# Altium schematic sheet MCU.SchDoc
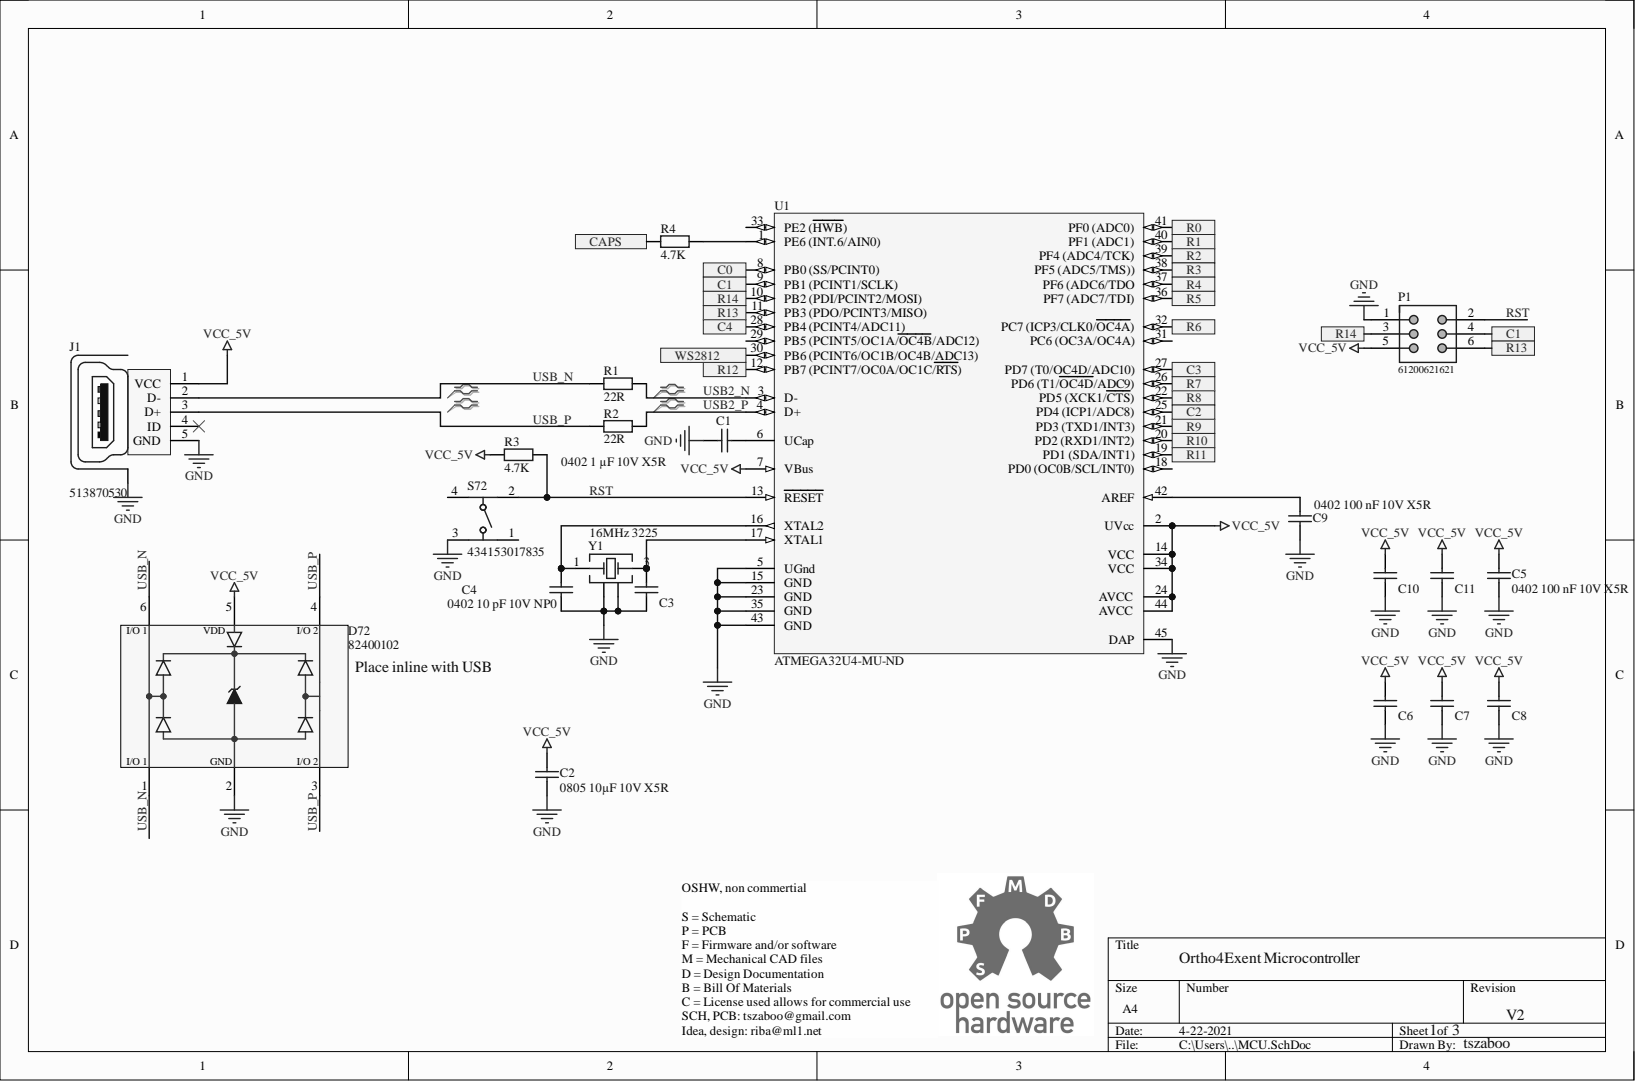
[diagram p0: MCU.SchDoc]

## Parts
C1  0402 1µF  value 1 µF  [CAPC0402N]
C2  0805 10µF  value 10 µF  [CAPC0805N]
C3  0402 10pF  [CAPC0402N]
C4  0402 10pF  value 10 pF  TECH: NP0  [CAPC0402N]
C5  0402 100nF  value 100 nF  [CAPC0402N]
C6  0402 100nF  [CAPC0402N]
C7  0402 100nF  [CAPC0402N]
C8  0402 100nF  [CAPC0402N]
C9  0402 100nF  value 100 nF  [CAPC0402N]
C10  0402 100nF  [CAPC0402N]
C11  0402 100nF  [CAPC0402N]
D72  82400102  [SOT23-6L]
J1  513870530  [513870530]
P1  61200621621  [61200621621]
R1  value 22R  [RESC0603N]  (hidden comment: RES 22R 0603)
R2  value 22R  [RESC0603N]  (hidden comment: RES 22R 0603)
R3  value 4.7K  [RESC0402N]  (hidden comment: RES 4.7K 0402)
R4  value 4.7K  [RESC0402N]  (hidden comment: RES 4.7K 0402)
S72  434153017835  [434153017835]
U1  ATMEGA32U4-MU-ND  [44PW_M]
Y1  16MHz 3225  [WE-XTAL_CFPX-180]

## Ports
C0, C1, C2, C3, C4, CAPS, R0, R1, R2, R3, R4, R5, R6, R7, R8, R9, R10, R11, R12, R13, R14, WS2812

## Nets
GND: C1.1 C2.1 C3.1 C4.1 C5.1 C6.1 C7.1 C8.1 C9.1 C10.1 C11.1 D72.2 J1.5(GND) J1.TAB P1.1 S72.3 U1.5(UGnd) U1.15(GND) U1.23(GND) U1.35(GND) U1.43(GND) U1.45(DAP) Y1.2 Y1.4
VCC_5V: C2.2 C5.2 C6.2 C7.2 C8.2 C10.2 C11.2 D72.5 J1.1(VCC) P1.5 R3.2 U1.2(UVcc) U1.7(VBus) U1.14(VCC) U1.24(AVCC) U1.34(VCC) U1.44(AVCC)
C0: U1.8(PB0_(SS/PCINT0)) ; port C0
C1: P1.4 U1.9(PB1_(PCINT1/SCLK)) ; port C1
C2: U1.25(PD4_(ICP1/ADC8)) ; port C2
C3: U1.27(PD7_(T0/OC4D/ADC10)) ; port C3
C4: U1.28(PB4_(PCINT4/ADC11)) ; port C4
CAPS: R4.2 ; port CAPS
R0: U1.41(PF0_(ADC0)) ; port R0
R1: U1.40(PF1_(ADC1)) ; port R1
R2: U1.39(PF4_(ADC4/TCK)) ; port R2
R3: U1.38(PF5_(ADC5/TMS))) ; port R3
R4: U1.37(PF6_(ADC6/TDO) ; port R4
R5: U1.36(PF7_(ADC7/TDI)) ; port R5
R6: U1.32(PC7_(ICP3/CLK0/OC4A)) ; port R6
R7: U1.26(PD6_(T1/OC4D/ADC9)) ; port R7
R8: U1.22(PD5_(XCK1/CTS)) ; port R8
R9: U1.21(PD3_(TXD1/INT3)) ; port R9
R10: U1.20(PD2_(RXD1/INT2)) ; port R10
R11: U1.19(PD1_(SDA/INT1)) ; port R11
R12: U1.12(PB7_(PCINT7/OC0A/OC1C/RTS)) ; port R12
R13: P1.6 U1.11(PB3_(PDO/PCINT3/MISO)) ; port R13
R14: P1.3 U1.10(PB2_(PDI/PCINT2/MOSI)) ; port R14
RST: P1.2 R3.1 S72.2 U1.13(RESET)
USB2_N: R1.2 U1.3(D-)
USB2_P: R2.2 U1.4(D+)
USB_N: D72.1 D72.6 J1.2(D-) R1.1
USB_P: D72.3 D72.4 J1.3(D+) R2.1
WS2812: U1.30(PB6_(PCINT6/OC1B/OC4B/ADC13)) ; port WS2812
NetC1_2: C1.2 U1.6(UCap)
NetC3_2: C3.2 U1.17(XTAL1) Y1.3
NetC4_2: C4.2 U1.16(XTAL2) Y1.1
NetC9_2: C9.2 U1.42(AREF)
NetR4_1: R4.1 U1.1(PE6_(INT.6/AIN0))

Not connected: J1 4(ID); S72 1 4; U1 18(PD0_(OC0B/SCL/INT0)) 29(PB5_(PCINT5/OC1A/OC4B/ADC12)) 31(PC6_(OC3A/OC4A)) 33(PE2_(HWB))

## Library data of the parts
C1 = 885012105012: MANUF: WE; COMPATIBLES: True; Database Table Name: WCAP0402$; DESCIPTION: CAP SMD 0402 1 µF 10V X5R; NoPins: 2; SCH: 0402 1 µF 10V X5R; SUPPLY: SUB; TECH: X5R; VOLTAGE: 10; Component Kind: Standard; DIGIKEY: 732-7489-2-ND; Library Name: WCAP.Dblib; MANUFCODE: 885012105012; SMD: YES; VALUE: 1 µF
C2 = 885012107010: MANUF: WE; COMPATIBLES: True; Database Table Name: WCAP0805$; DESCIPTION: CAP SMD 0805 10 µF 10 V X5R; NoPins: 2; SCH: 0805 10µF 10V X5R; SUPPLY: SUB; TECH: X5R; VOLTAGE: 10; Component Kind: Standard; DIGIKEY: 732-7620-2-ND; Library Name: WCAP.Dblib; MANUFCODE: 885012107010; SMD: YES; VALUE: 10 µF
C3 = 885012005007: MANUF: WE; COMPATIBLES: True; Database Table Name: WCAP0402$; DESCIPTION: CAP SMD 0402 10 pF 10V NP0; NoPins: 2; SCH: 0402 10 pF 10V NP0; SUPPLY: SUB; TECH: NP0; VOLTAGE: 10; Component Kind: Standard; DIGIKEY: 732-7425-2-ND; Library Name: WCAP.Dblib; MANUFCODE: 885012005007; SMD: YES; VALUE: 10 pF
C4 = 885012005007: MANUF: WE; COMPATIBLES: True; Database Table Name: WCAP0402$; DESCIPTION: CAP SMD 0402 10 pF 10V NP0; NoPins: 2; SCH: 0402 10 pF 10V NP0; SUPPLY: SUB; VOLTAGE: 10; Component Kind: Standard; DIGIKEY: 732-7425-2-ND; Library Name: WCAP.Dblib; MANUFCODE: 885012005007; SMD: YES
C5-C11 = 885012105010: MANUF: WE; COMPATIBLES: True; Database Table Name: WCAP0402$; DESCIPTION: CAP SMD 0402 100 nF 10V X5R; NoPins: 2; SCH: 0402 100 nF 10V X5R; SUPPLY: SUB; TECH: X5R; VOLTAGE: 10; Component Kind: Standard; DIGIKEY: 732-7487-2-ND; Library Name: WCAP.Dblib; MANUFCODE: 885012105010; SMD: YES
  C6-C8 C10 C11: VALUE: 100 nF
D72 = WE-TVS, SOT23-6L, 82400102: WE-TVS TVS Diode, High Speed Series, SOT23-6L, VDC = 5V; MANUF: WE; COMPATIBLES: False; Component Kind: Standard; DESCIPTION: WE-TVS TVS Diode, High Speed Series, SOT23-6L, VDC = 5V; DIGIKEY: 732-4473-1-ND; Library Name: WE-TVS_rev16a.intlib; MANUFCODE: 82400102
J1 = 513870530: Mini USB, B-Receptacle, Right-Angle, Short Body, Surface Mount Solder Tails and Through Hole Shell Tabs, with Cover Tape, Reflow DIP Type, Lead-Free; MANUF: MOLEX; COMPATIBLES: False; DESCIPTION: USB - mini B USB 2.0 OTG Receptacle Connector 5 Position Surface Mount; Component Kind: Standard; DIGIKEY: WM3756TR-ND; Library Name: 513870530.SchLib; MANUFCODE: 513870530
P1 = 61200621621: Male Box Header WR-BHD, THT, Vertical, pitch 2.54 mm, 6 pins; COMPATIBLES: True; Component Kind: Standard; Library Name: Altium_WR-BHD (rev19a).IntLib
R1 R2 = CRCW060322R0FKEA: Resistor; MANUF: VISHAY; COMPATIBLES: True; Database Table Name: CRCW0603$; DESCIPTION: RES SMD 22 OHM 1% 1/10W 100PPM 0603; NoPins: 2; SUPPLY: SUB; Component Kind: Standard; DIGIKEY: 541-22.0HCT-ND; FARNELL: #N/A; Library Name: VISHAY CRCW.Dblib; MANUFCODE: CRCW060322R0FKEA; SCH: RES 22R 0603; SMD: YES
R3 R4 = CRCW04024K70FKED: Resistor; MANUF: VISHAY; COMPATIBLES: True; Database Table Name: CRCW0402$; DESCIPTION: RES SMD 4.7K OHM 1% 1/16W 100PPM 0402; NoPins: 2; SUPPLY: SUB; Component Kind: Standard; DIGIKEY: 541-4.70KLCT-ND; FARNELL: TBD; Library Name: VISHAY CRCW.Dblib; MANUFCODE: CRCW04024K70FKED; SCH: RES 4.7K 0402; SMD: YES
S72 = 434153017835: WS-TASV J-Bend SMT Tact Switch, 3.5x2.9x1.7mm, 350gf; MANUF: WE; COMPATIBLES: True; DESCIPTION: SWITCH TACTILE SPST-NO 0.05A 12V; Component Kind: Standard; DIGIKEY: 732-10143-1-ND; Library Name: Altium_WS-TASV (rev20b).IntLib; MANUFCODE: 434153017835
U1 = CMP-0095-00166-2: 8-bit AVR Microcontroller, 2.7-5.5V, 16MHz, 32KB Flash, 1KB EEPROM, 2.5KB SRAM, USB Controller, 44-pin QFN, Industrial Grade (-40°C to 85°C), Ext Osc; MANUF: Microchip; COMPATIBLES: False; DESCIPTION: IC MCU 8BIT 32KB FLASH 44VQFN; NoPins: 44; Component Kind: Standard; DIGIKEY: ATMEGA32U4-MU-ND; Library Name: Altium Content Vault; MANUFCODE: ATMEGA32U4-MU-ND
Y1 = 830055951: WE-XTAL Quartz Crystal, SMT, CFPX-180, 16MHz, +/-20ppm; MANUF: WE/IQD; COMPATIBLES: True; DESCIPTION: CRYSTAL 16.0000MHZ 18PF SMD; Component Kind: Standard; DIGIKEY: 1923-1308-1-ND; Library Name: Altium_WE-XTAL (rev20c).IntLib; MANUFCODE: 830055951
